# Altium schematic sheet Lipo_Power.SchDoc
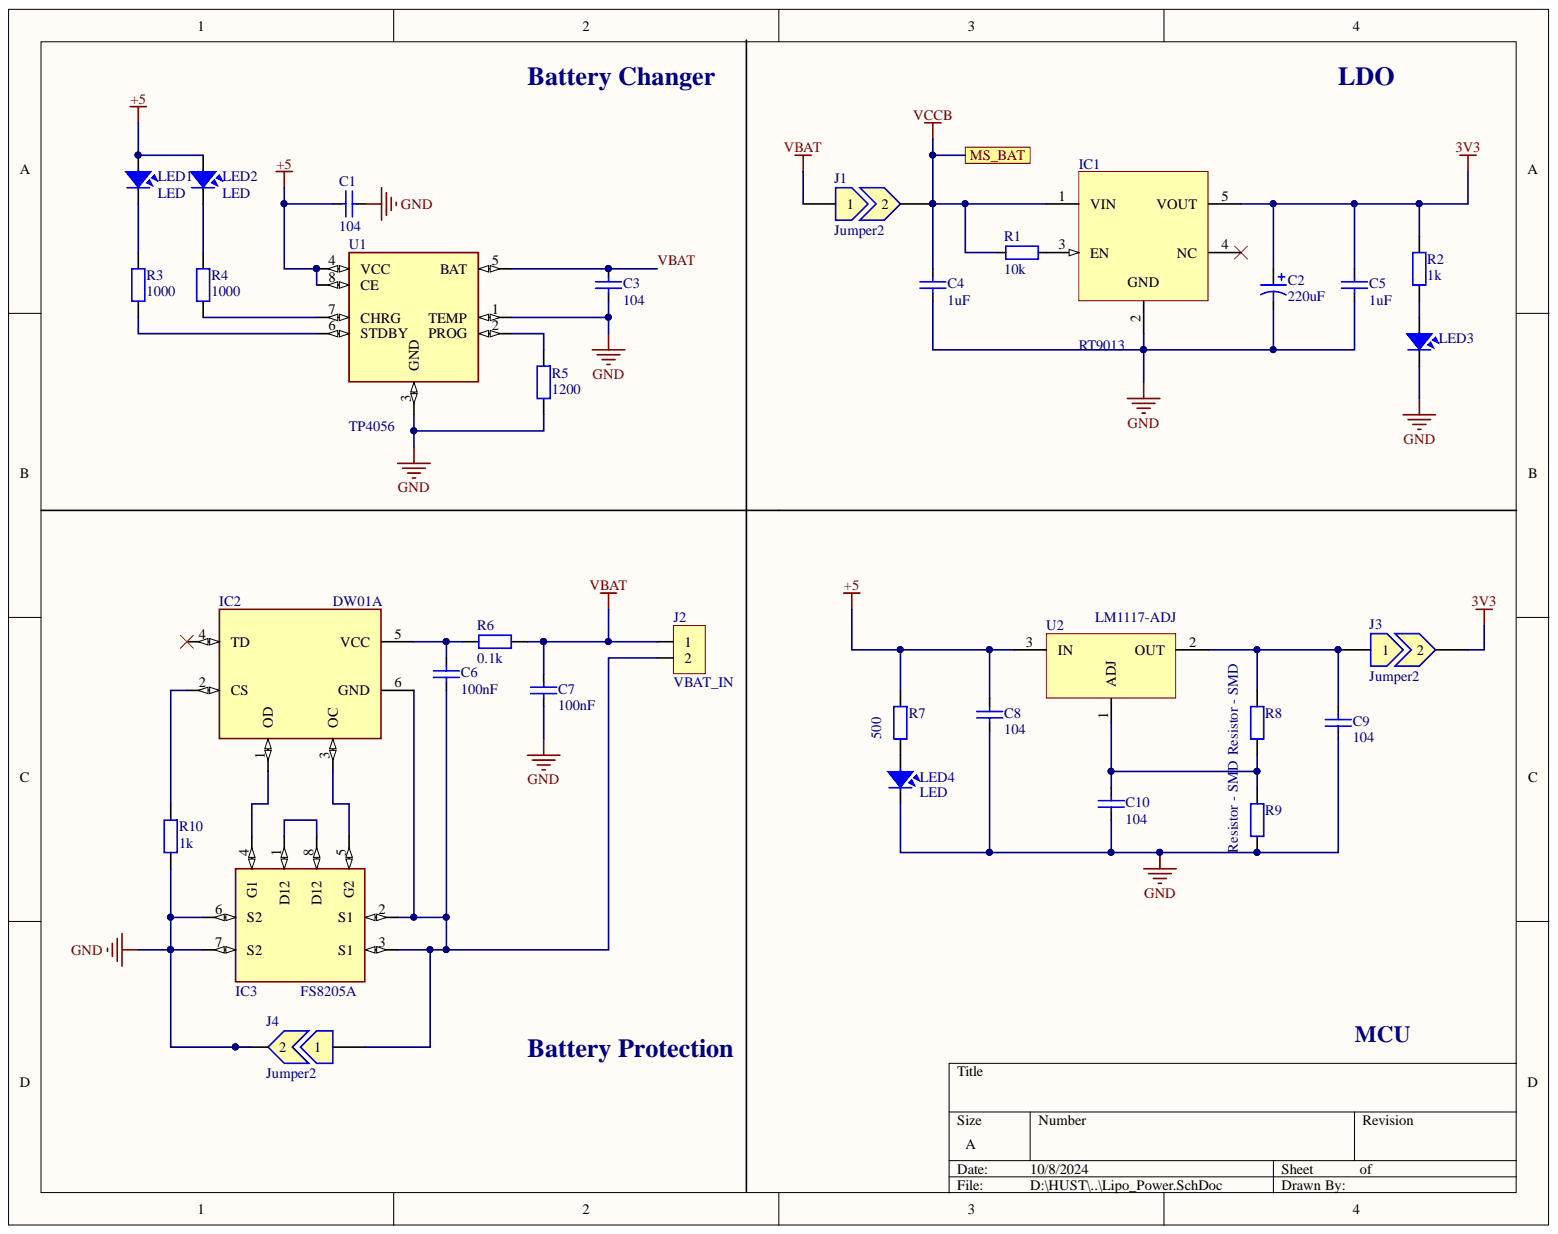
[diagram p0: Lipo_Power.SchDoc]

## Parts
C1  104  [CAP_0805]
C2  220uF  [CAP_TANTALUM_3216]
C3  104  [CAP_0805]
C4  1uF  [CAP_0805]
C5  1uF  [CAP_0805]
C6  100nF  [CAP_0805]
C7  100nF  [CAP_0805]
C8  104  [CAP_0805]
C9  104  [CAP_0805]
C10  104  [CAP_0805]
IC1  RT9013  [SOT23-5]
IC2  DW01A  [SOT23-6]
IC3  FS8205A  [FS8205A]
J1  Jumper2  [JUMPER2]
J2  VBAT_IN  [JP2-D1T]
J3  Jumper2  [RES-SMD-0201]
J4  Jumper2  [RES-SMD-0201]
LED1  LED  [LED-0805-Red]
LED2  LED  [LED-0805-Blue]
LED3  (no value)  [LED-0805-Red]
LED4  LED  [LED-0805-Red]
R1  10k  [RES-SMD-0805]
R2  1k  [RES-SMD-0805]
R3  1000  [RES-SMD-0805]
R4  1000  [RES-SMD-0805]
R5  1200  [RES-SMD-0805]
R6  0.1k  [RES-SMD-0805]
R7  500  [RES-SMD-0805]
R8  Resistor - SMD  [RES-SMD-0805]
R9  Resistor - SMD  [RES-SMD-0805]
R10  1k  [RES-SMD-0805]
U1  TP4056  [TP4056]
U2  LM1117-ADJ  [LM1117-SOP]

## Ports
MS_BAT

## Nets
3V3: C2.1 C5.1 IC1.5(VOUT) J3.2 R2.2
+5: C1.1 C8.1 LED1.1 LED2.1 R7.1 U1.4(VCC) U1.8(CE) U2.3
GND: C1.2 C2.2 C3.1 C4.2 C5.2 C7.1 C8.2 C9.1 C10.1 IC1.2 IC3.6(S2) IC3.7(S2) J4.2 LED3.2 LED4.2 R5.2 R9.2 R10.2 U1.1(TEMP) U1.3(GND)
VBAT: C3.2 C7.2 J1.1 J2.1 R6.2 U1.5(BAT)
VCCB: C4.1 IC1.1(VIN) J1.2 R1.2 ; port MS_BAT
NetC6_1: C6.1 IC2.6(GND) IC3.2(S1) IC3.3(S1) J2.2 J4.1
NetC6_2: C6.2 IC2.5(VCC) R6.1
NetC9_2: C9.2 J3.1 R8.1 U2.2
NetC10_2: C10.2 R8.2 R9.1 U2.1
NetIC1_3: IC1.3(EN) R1.1
NetIC2_1: IC2.1(OD) IC3.4(G1)
NetIC2_2: IC2.2(CS) R10.1
NetIC2_3: IC2.3(OC) IC3.5(G2)
NetIC3_1: IC3.1(D12) IC3.8(D12)
NetLED1_2: LED1.2 R3.1
NetLED2_2: LED2.2 R4.1
NetLED3_1: LED3.1 R2.1
NetLED4_1: LED4.1 R7.2
NetR3_2: R3.2 U1.6(STDBY)
NetR4_2: R4.2 U1.7(CHRG)
NetR5_1: R5.1 U1.2(PROG)

Not connected: IC1 4(NC); IC2 4(TD)

## Library data of the parts
C1 C3-C10 = CapSMD: Capacitor; Value: 33p; Component Kind: Standard; LatestRevisionDate: 17-Jul-2002; LatestRevisionNote: Re-released for DXP Platform.; Library Name: My Library.SchLib; PackageReference: RAD-0.3; Published: 8-Jun-2000; Publisher: Altium Limited
C2 = Cap Pol: Polarized Capacitor (Axial); Component Kind: Standard; LatestRevisionDate: 17-Jul-2002; LatestRevisionNote: Re-released for DXP Platform.; Library Name: My Library.SchLib; PackageReference: POLAR0.8; Published: 8-Jun-2000; Publisher: Altium Limited
IC1 = RT9013: https://linhkien.cxt.vn/5435-rt9013-33gb-ic-reg-linear-33v-500ma-sot23-5.html; Component Kind: Standard; Library Name: My Library.SchLib
IC2 = DW01A: One Cell Lithium-ion/Polymer Battery Protection IC; Component Kind: Standard; Library Name: My Library.SchLib
J1 J3 J4 = Jumper2: Jumper, 2-Pin; Component Kind: Standard
  J1: Library Name: Main.SchLib
  J3 J4: Library Name: My Library.SchLib
J2 = JP2: WR-PHD 2.54 mm Angled Socket Header; Manufacturer: W¨¹rth Elektronik eiSos GmbH; partNo: 613002143121; ComponentLink1 Description: Manufacturer; ComponentLink2 Description: Datasheet; NoPins: 02; Component Kind: Standard; ComponentLink1URL: http://www.we-online.com; ComponentLink2URL: http://katalog.we-online.de/em/datasheet/6130xx143121.pdf; Created Date: 29 March 2013; Library Name: My Library.SchLib; Created by: Karrer Zheng
LED1-LED4 = LED: LED Single colour Green and Red; Value: LED 3 ly Single Colour; Component Kind: Standard
  LED1 LED2 LED4: Library Name: My Library.SchLib
  LED3: Library Name: Main.SchLib
R1 = Resistor - SMD: Resistor; Manufacturer: Generic Components; Class: Passive; Component Kind: Standard; Library Name: My Library.SchLib; Published: 08/06/2000; Publisher: Altium Hobart Technology Centre
R2-R10 = Resistor - SMD: Resistor SMD; Manufacturer: Generic Components; Class: Passive; Component Kind: Standard; Published: 08/06/2000; Publisher: Altium Hobart Technology Centre
  R2: Library Name: Main.SchLib
  R3-R10: Library Name: My Library.SchLib
U1 = TP4056: Complete single cell Li-Ion battery with a constant current / constant voltage linear charger; Component Kind: Standard; Library Name: My Library.SchLib
U2 = LM1117-ADJ: 800mA Low-Dropout Linear Regulator; Component Kind: Standard; Library Name: My Library.SchLib; Website: http://group3i.net; Creator: Nguyen Ba Bien
IC3 = FS8205A: Component Kind: Standard; Library Name: My Library.SchLib
